# Altium schematic sheet DMP_SEM_ETHERNET.SchDoc(DMP_SEM_ETHERNET)
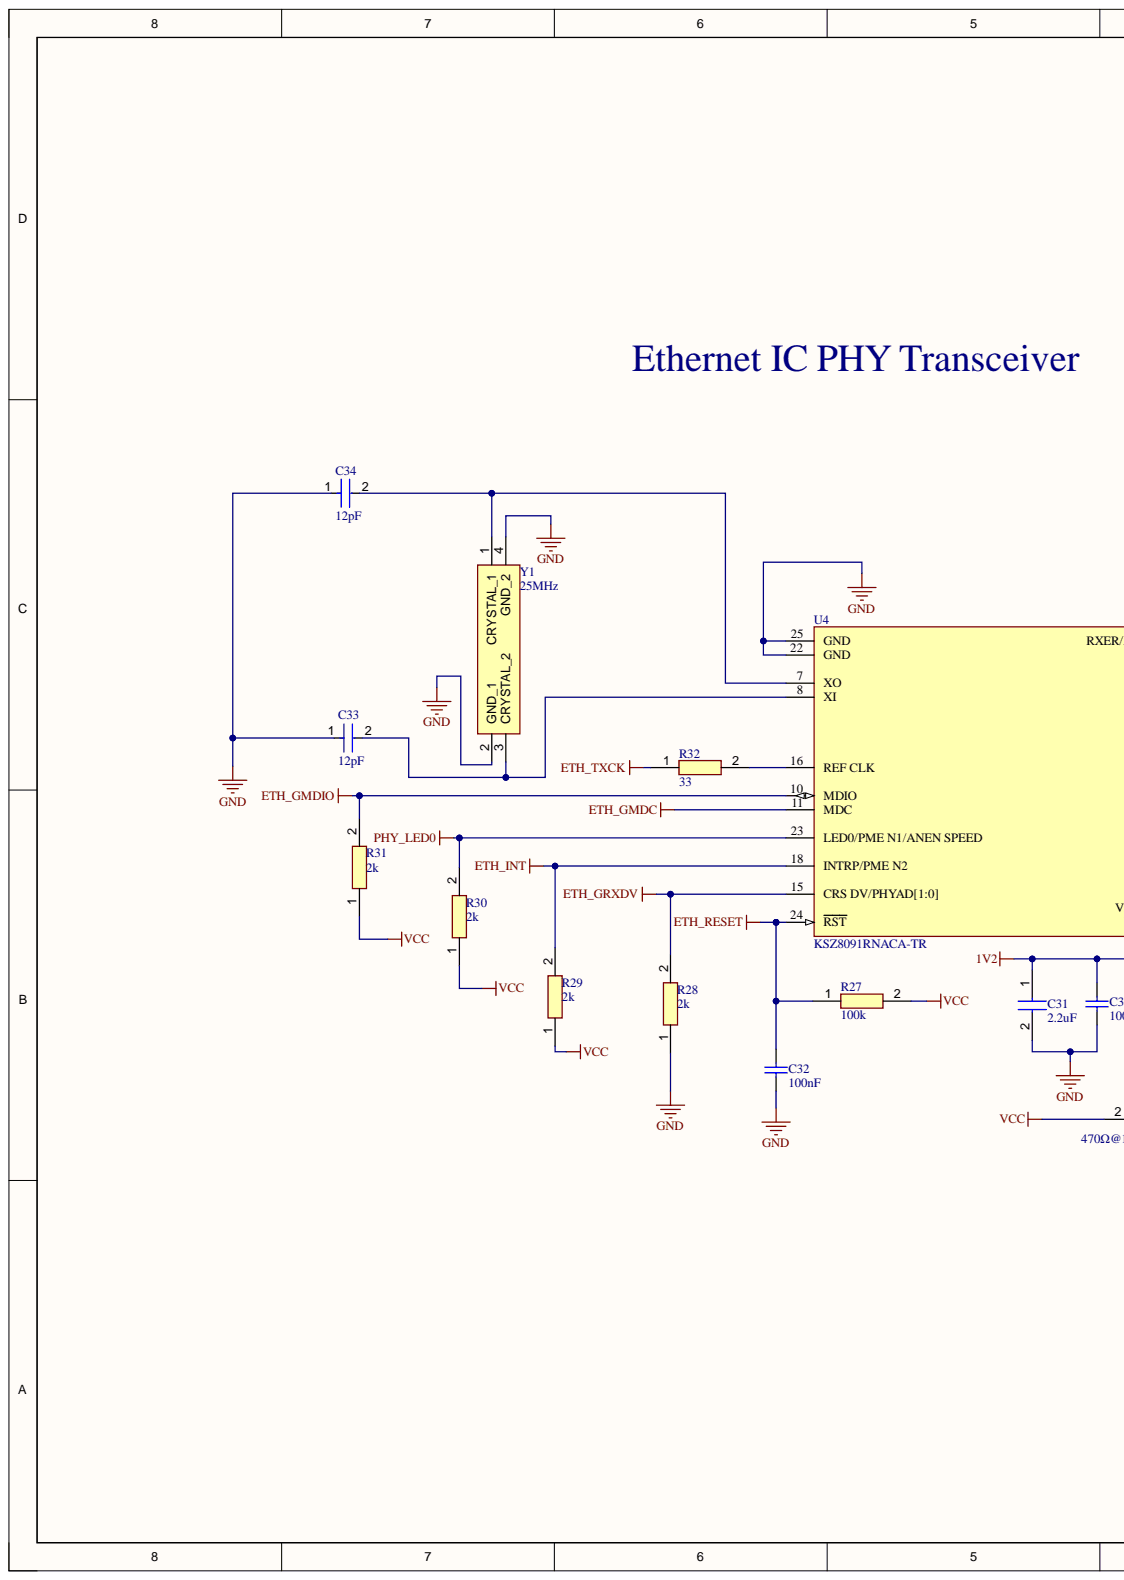
[diagram p0: DMP_SEM_ETHERNET.SchDoc, left]
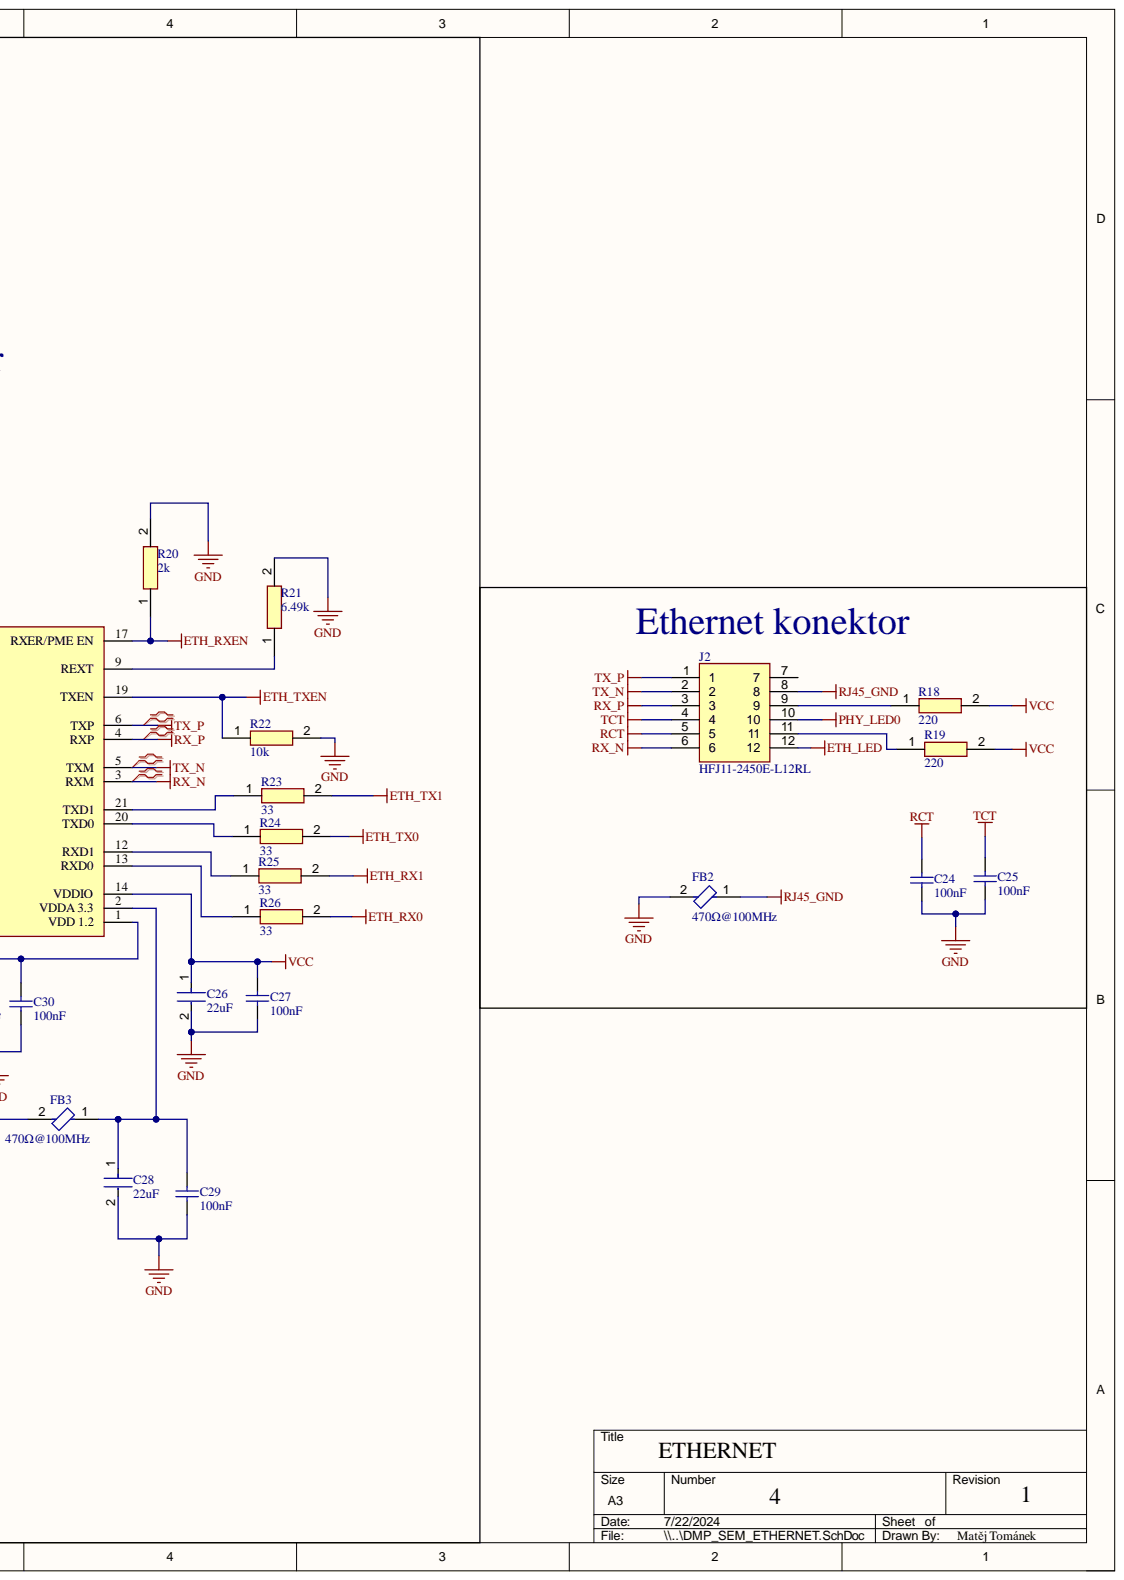
[diagram p1: DMP_SEM_ETHERNET.SchDoc, right]

## Parts
C24  100nF  [CAPC1050X55X23ML05T13]
C25  100nF  [CAPC1050X55X23ML05T13]
C26  22uF  [FP-GRM188-0_2-IPC_B]
C27  100nF  [CAPC1050X55X23ML05T13]
C28  22uF  [FP-GRM188-0_2-IPC_B]
C29  100nF  [CAPC1050X55X23ML05T13]
C30  100nF  [CAPC1050X55X23ML05T13]
C31  2.2uF  [CAPC1608X90N]
C32  100nF  [CAPC1050X55X23ML05T13]
C33  12pF  [FP-C0402-BB-IPC_A]
C34  12pF  [FP-C0402-BB-IPC_A]
FB2  470Ω@100MHz  [FP-BLM18-0_15-t0_8-IPC_A]
FB3  470Ω@100MHz  [FP-BLM18-0_15-t0_8-IPC_A]
J2  HFJ11-2450E-L12RL  [HFJ11-2450E-L11RL]
R18  220  [RESC1608X50N]
R19  220  [RESC1608X50N]
R20  2k  [ERA2AED122X]
R21  6.49k  [ERA3AEB101V]
R22  10k  [RESC1608X50N]
R23  33  [ERJS02_U02_(0402)]
R24  33  [ERJS02_U02_(0402)]
R25  33  [ERJS02_U02_(0402)]
R26  33  [ERJS02_U02_(0402)]
R27  100k  [ERJ2RKD1004X]
R28  2k  [ERA2AED122X]
R29  2k  [ERA2AED122X]
R30  2k  [ERA2AED122X]
R31  2k  [ERA2AED122X]
R32  33  [ERJS02_U02_(0402)]
U4  KSZ8091RNACA-TR  [FP-QFN44-24LD-PL-1-IPC_A]
Y1  25MHz  [LFXTAL071758Cutt]

## Nets
1V2: C30.2 C31.1 U4.1(VDD_1.2)
ETH_GMDC: U4.11(MDC)
ETH_GMDIO: R31.2 U4.10(MDIO)
ETH_GRXDV: R28.2 U4.15(CRS_DV/PHYAD[1:0])
ETH_INT: R29.2 U4.18(INTRP/PME_N2)
ETH_LED: J2.12
ETH_RESET: C32.1 R27.1 U4.24(RST)
ETH_RX0: R26.2
ETH_RX1: R25.2
ETH_RXEN: R20.1 U4.17(RXER/PME_EN)
ETH_TX0: R24.2
ETH_TX1: R23.2
ETH_TXCK: R32.1
ETH_TXEN: R22.1 U4.19(TXEN)
GND: C24.2 C25.2 C26.2 C27.1 C28.2 C29.1 C30.1 C31.2 C32.2 C33.1 C34.1 FB2.2 R20.2 R21.2 R22.2 R28.1 U4.22(GND) U4.25(GND) Y1.2(GND_1) Y1.4(GND_2)
PHY_LED0: J2.10 R30.2 U4.23(LED0/PME_N1/ANEN_SPEED)
RCT: C24.1 J2.5
RJ45_GND: FB2.1 J2.8
RX_N: J2.6 U4.3(RXM)
RX_P: J2.3 U4.4(RXP)
TCT: C25.1 J2.4
TX_N: J2.2 U4.5(TXM)
TX_P: J2.1 U4.6(TXP)
VCC: C26.1 C27.2 FB3.2 R18.2 R19.2 R27.2 R29.1 R30.1 R31.1 U4.14(VDDIO)
NetC28_1: C28.1 C29.2 FB3.1 U4.2(VDDA_3.3)
NetC33_2: C33.2 U4.8(XI) Y1.3(CRYSTAL_2)
NetC34_2: C34.2 U4.7(XO) Y1.1(CRYSTAL_1)
NetJ2_9: J2.9 R18.1
NetJ2_11: J2.11 R19.1
NetR21_1: R21.1 U4.9(REXT)
NetR23_1: R23.1 U4.21(TXD1)
NetR24_1: R24.1 U4.20(TXD0)
NetR25_1: R25.1 U4.12(RXD1)
NetR26_1: R26.1 U4.13(RXD0)
NetR32_2: R32.2 U4.16(REF_CLK)

Not connected: J2 7

## Library data of the parts
C24 C25 C27 C29 C30 C32 = CMP-2000-05439-1: Chip Capacitor, 100 nF, +/- 10%, 16 V, -55 to 125 degC, 0402 (1005 Metric), RoHS, Tape and ReelCL05B104KO5NNNC; Manufacturer: Samsung Electro-Mechanics; Capacitance: 100000pF; Dielectric: X7R; Rated Voltage: 16V; Case Size/Dimension: 0402; Component Kind: Standard; Datasheet URL: https://datasheet.ciiva.com/29198/458718-da-01-en-vs-kondensator-0402-29198423.pdf; Datasheet Version: Nov-10; Library Name: Altium Content Vault; Manufacturer URL: http://www.samsungsem.com/global/index.jsp; Tolerance (%): ±10%
C26 = CMP-2000-06337-3: Chip Multilayer Ceramic Capacitors for General Purpose, 0603, 22uF, X5R, 15%, 20%, 10V     GRM188R61A226ME15D; Capacitance: 22uF; Case Code (Imperial): 0603; Case Code (Metric): 1608; Case/Package: 0603; Component Kind: Standard; Depth: 0.8mm; Dielectric: X5R; Height: 0.8mm; Lead Free: Lead Free; Length: 1.6mm; Library Name: Altium Content Vault; Material: Ceramic; Max Operating Temperature: 85°C; Min Operating Temperature: -55°C; Mount: Surface Mount; Package Quantity: 4000; Packaging: Tape and Reel; REACH SVHC: No SVHC; Termination: SMD/SMT; Thickness: 0.039inch; Tolerance: 20%; Voltage Rating: 10V; Voltage Rating (DC): 10V; Weight: 0.000222oz; Width: 0.8mm
C28 = CMP-2000-06337-3: Chip Multilayer Ceramic Capacitors for General Purpose, 0603, 22uF, X5R, 15%, 20%, 10V       GRM188R61A226ME15D; Capacitance: 22uF; Case Code (Imperial): 0603; Case Code (Metric): 1608; Case/Package: 0603; Component Kind: Standard; Depth: 0.8mm; Dielectric: X5R; Height: 0.8mm; Lead Free: Lead Free; Length: 1.6mm; Library Name: Altium Content Vault; Material: Ceramic; Max Operating Temperature: 85°C; Min Operating Temperature: -55°C; Mount: Surface Mount; Package Quantity: 4000; Packaging: Tape and Reel; REACH SVHC: No SVHC; Termination: SMD/SMT; Thickness: 0.039inch; Tolerance: 20%; Voltage Rating: 10V; Voltage Rating (DC): 10V; Weight: 0.000222oz; Width: 0.8mm
C31 = GRM188R61C225KAADJ: Capacitor GRM188R61C225KAADJ; Manufacturer_Name: Murata Electronics; Component Kind: Standard; Datasheet Link: http://componentsearchengine.com/Datasheets/1/GRM188R61C225KAADJ.pdf; Height: 0.9mm; Manufacturer_Part_Number: GRM188R61C225KAADJ; Mouser Part Number: 81-GRM188R61C225KADJ; Mouser Price/Stock: https://www.mouser.co.uk/ProductDetail/Murata-Electronics/GRM188R61C225KAADJ?qs=QzBtWTOodeWhnkdg0se%2F2g%3D%3D
C33 C34 = CMP-1034-00770-4: CAP CER 12PF 50V C0G/NP0 0402 C0402C120J5GACTU; Manufacturer: Kemet; Material: Ceramic; Temperature Characteristic: C0G; Temperature Coefficient: 15%; Tolerance: 0.05; Automotive: No; Capacitance: 12 pF; Category: Cap; Component Kind: Standard; ComponentLink2Description: Datasheet; ComponentLink2URL: https://content.kemet.com/datasheets/KEM_C1002_X7R_SMD.pdf; Device Class L1: Passive Components; Device Class L2: Capacitors; Device Class L3: Ceramic Capacitors; Digikey Description: CAP CER 12PF 50V C0G/NP0 0402; Height: 0.55mm; Ipc Land Pattern Name: CAPC10050X50; Lead Free: Yes; Library Name: Altium Content Vault; Manufacturer Part Number: C0402C120J5GACTU; Mouser Description: Multilayer Ceramic Capacitor, C Series, 12 pF, - 5%, C0G / NP0, 50 V, 0402 [1005 Metric]; Octopart: https://octopart.com/c0402c120j5gactu-kemet-141377; Package: C0402-BB; RoHS: TRUE; Temperature: -55°C to +125°C; Temperature Range High: +125°C; Temperature Range Low: -55°C; Value: 12 pF; Voltage Rating: 50 V
FB2 FB3 = CMP-0686-00587-3: Chip Ferrite Bead, 0603, 470Ω @ 100MHz, 0.2Ω, 25%, 1A             BLM18PG471SN1D; DC Resistance (DCR): 200mR; Impedance: 470R; Material: Steel; Number of Circuits: 1; Case Code (Imperial): 0603; Case Code (Metric): 1608; Case/Package: 0603; Component Kind: Standard; Core Material: Ferrite; Current Rating: 1A; DC Current: 1A; DC Resistance-Max: 200mR; Depth: 0.8mm; Height: 0.8mm; Lead Free: Lead Free; Length: 1.6mm; Library Name: Altium Content Vault; Max Current Rating: 1A; Max DC Current: 1A; Max Operating Temperature: 125°C; Military Standard: Not; Min Operating Temperature: -55°C; Number of Lines: 1; Number of Pins: 2; Package Quantity: 1; Packaging: Tape and Reel; Radiation Hardening: No; REACH SVHC: No SVHC; Resistance: 200mR; RoHS Compliant: Yes; Series: BLM18P; Termination: SMD/SMT; Test Frequency: 100MHz; Tolerance: 25%; Width: 0.8mm
J2 = HFJ11-2450E-L12RL: Connector; Manufacturer_Name: Halo Electronics; Arrow Part Number: HFJ11-2450E-L12RL; Arrow Price/Stock: https://www.arrow.com/en/products/hfj11-2450e-l12rl/halo-electronics; Component Kind: Standard; Datasheet Link: https://componentsearchengine.com/Datasheets/1/HFJ11-2450E-L12RL.pdf; Library Name: SamacSys.SchLib; Manufacturer_Part_Number: HFJ11-2450E-L12RL; Mouser Part Number: 493-HFJ112450EL12RL; Mouser Price/Stock: https://www.mouser.co.uk/ProductDetail/HALO-Electronics/HFJ11-2450E-L12RL?qs=8uu3sA0mUduNJw%2FtWFBLbA%3D%3D
R18 R19 = CRCW0603220RFKEC: Resistor CRCW0603220RFKEC; Manufacturer_Name: Vishay; Arrow Part Number: CRCW0603220RFKEC; Arrow Price/Stock: https://www.arrow.com/en/products/crcw0603220rfkec/vishay; Component Kind: Standard; Datasheet Link: http://www.vishay.com/docs/20035/dcrcwe3.pdf; Height: 0.5mm; Manufacturer_Part_Number: CRCW0603220RFKEC
R20 R28-R31 = ERA-2AEB202X: Resistor ERA-2AEB202X; Manufacturer_Name: Panasonic; Arrow Part Number: ERA-2AEB202X; Arrow Price/Stock: https://www.arrow.com/en/products/era-2aeb202x/panasonic?region=nac; Component Kind: Standard; Datasheet Link: http://industrial.panasonic.com/cdbs/www-data/pdf/RDM0000/AOA0000C307.pdf; Height: 0.4mm; Manufacturer_Part_Number: ERA-2AEB202X; Mouser Part Number: 667-ERA-2AEB202X; Mouser Price/Stock: https://www.mouser.co.uk/ProductDetail/Panasonic/ERA-2AEB202X?qs=YFwoyZoVY0hwTijpJ0mp2Q%3D%3D
R21 = ERA-3AEB6491V: Resistor ERA-3AEB6491V; Manufacturer_Name: Panasonic; Arrow Part Number: ERA-3AEB6491V; Arrow Price/Stock: https://www.arrow.com/en/products/era-3aeb6491v/panasonic?region=nac; Component Kind: Standard; Datasheet Link: https://industrial.panasonic.com/cdbs/www-data/pdf/RDM0000/AOA0000C307.pdf; Height: 0.55mm; Manufacturer_Part_Number: ERA-3AEB6491V; Mouser Part Number: 667-ERA-3AEB6491V; Mouser Price/Stock: https://www.mouser.co.uk/ProductDetail/Panasonic/ERA-3AEB6491V?qs=nuXzIS8loXFxZ26VDEo1yw%3D%3D
R22 = CRCW060310K0FKEA: Resistor CRCW060310K0FKEA; Manufacturer_Name: Vishay; Arrow Part Number: CRCW060310K0FKEA; Arrow Price/Stock: https://www.arrow.com/en/products/crcw060310k0fkea/vishay?region=nac; Component Kind: Standard; ComponentLink1Description: shop; ComponentLink1URL: https://cz.mouser.com/ProductDetail/Vishay-Dale/CRCW060310K0FKEA?qs=pAO1Z172M84BVnhDjtnsoQ%3D%3D; Datasheet Link: http://www.vishay.com/docs/20035/dcrcwe3.pdf; Height: 0.5mm; Manufacturer_Part_Number: CRCW060310K0FKEA; Mouser Part Number: 71-CRCW0603-10K-E3; Mouser Price/Stock: https://www.mouser.co.uk/ProductDetail/Vishay-Dale/CRCW060310K0FKEA?qs=pAO1Z172M84BVnhDjtnsoQ%3D%3D
R23-R26 R32 = ERJ-U02F33R0X: Resistor ERJ-U02F33R0X; Manufacturer_Name: Panasonic; Arrow Part Number: ERJ-U02F33R0X; Arrow Price/Stock: https://www.arrow.com/en/products/erj-u02f33r0x/panasonic; Component Kind: Standard; Datasheet Link: https://industrial.panasonic.com/cdbs/www-data/pdf/RDP0000/AOA0000C334.pdf; Height: 0.4mm; Manufacturer_Part_Number: ERJ-U02F33R0X; Mouser Part Number: 667-ERJ-U02F33R0X; Mouser Price/Stock: https://www.mouser.co.uk/ProductDetail/Panasonic/ERJ-U02F33R0X?qs=bKFmlxG1yYAQpbiolqWZyA%3D%3D
R27 = ERJ-2RKF1003X: Resistor ERJ-2RKF1003X; Manufacturer_Name: Panasonic; Arrow Part Number: ERJ-2RKF1003X; Arrow Price/Stock: https://www.arrow.com/en/products/erj-2rkf1003x/panasonic?region=europe; Component Kind: Standard; Datasheet Link: https://industrial.panasonic.com/cdbs/www-data/pdf/RDA0000/AOA0000C86.pdf; Height: 0.4mm; Manufacturer_Part_Number: ERJ-2RKF1003X; Mouser Part Number: 667-ERJ-2RKF1003X; Mouser Price/Stock: https://www.mouser.co.uk/ProductDetail/Panasonic/ERJ-2RKF1003X?qs=H7k1u0Mp9JQnXoBKdf15Qg%3D%3D
U4 = CMP-06281-000080-1: IC TRANSCEIVER FULL 1/1 24QFN; Data Rate: 100Mbps; Max Supply Current: 47A; Max Supply Voltage: 3.465V; Min Supply Voltage: 3.135V; Number of Transceivers: 1; Operating Supply Voltage: 3.3V; Simplex/Duplex: Full Duplex; Case/Package: QFN; Component Kind: Standard; Lead Free: Lead Free; Length: 4mm; Library Name: Altium Content Vault; Max Operating Temperature: 70°C; Min Operating Temperature: 0°C; Mount: Surface Mount; Number of Pins: 32; Package Quantity: 1000; Packaging: Tape and Reel; REACH SVHC: No SVHC; RoHS Compliant: Yes; Series: -; Standard: 10/100 BASE-TX; Width: 4mm
Y1 = LFXTAL071758Cutt: Crystal or Oscillator LFXTAL071758Cutt; Manufacturer_Name: IQD; Component Kind: Standard; Datasheet Link: https://www.iqdfrequencyproducts.com/products/pn/LFXTAL071758Reel.pdf; Height: 0.8mm; Manufacturer_Part_Number: LFXTAL071758Cutt; Mouser Part Number: 449-LFXTAL071758CUTT; Mouser Price/Stock: https://www.mouser.co.uk/ProductDetail/IQD/LFXTAL071758Cutt?qs=nFovR%252B4R4UN8RYPh9I588Q%3D%3D
